# Altium schematic sheet Schematic_Orbita_Microcontroller.SchDoc
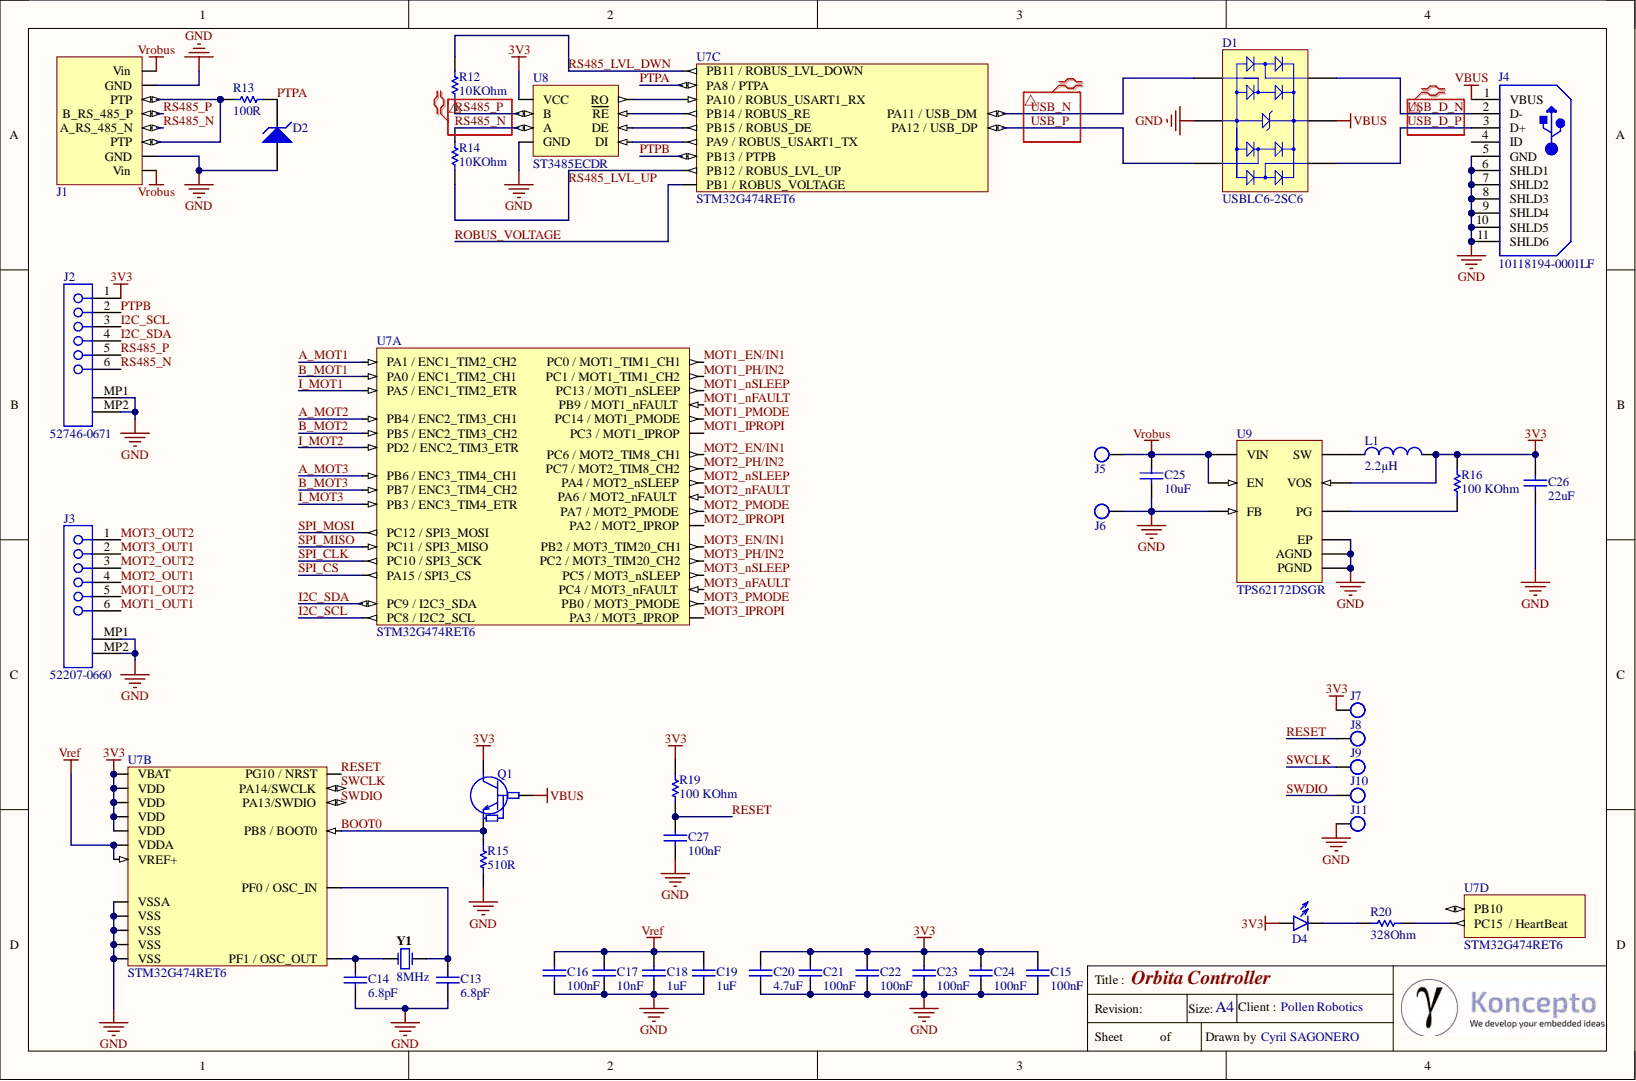
[diagram p0: Schematic_Orbita_Microcontroller.SchDoc]

## Parts
C13  Capacitance: 6.8pF  [CAPC1005X56X25LL10T15]  (hidden comment: 04025A6R8CAT2A)
C14  Capacitance: 6.8pF  [CAPC1005X56X25LL10T15]  (hidden comment: 04025A6R8CAT2A)
C15  Capacitance: 100nF  [CAPC1005X55X25ML05T10]  (hidden comment: GRM155R71C104KA88D)
C16  Capacitance: 100nF  [CAPC1005X60X30ML05T10]  (hidden comment: C0402C104K8PACTU)
C17  Capacitance: 10nF  [CAPC1005X55X30LL05T10]  (hidden comment: C0402C103K3RACTU)
C18  Capacitance: 1uF  [CAPC1005X60X30LL05T10]  (hidden comment: C0402C105K8PAC7867)
C19  Capacitance: 1uF  [CAPC1005X60X30LL05T10]  (hidden comment: C0402C105K8PAC7867)
C20  Capacitance: 4.7uF  [CAPC1005X55X25NL05T10]  (hidden comment: GRM155R60J475ME47D)
C21  Capacitance: 100nF  [CAPC1005X60X30ML05T10]  (hidden comment: C0402C104K8PACTU)
C22  Capacitance: 100nF  [CAPC1005X60X30ML05T10]  (hidden comment: C0402C104K8PACTU)
C23  Capacitance: 100nF  [CAPC1005X60X30ML05T10]  (hidden comment: C0402C104K8PACTU)
C24  Capacitance: 100nF  [CAPC1005X60X30ML05T10]  (hidden comment: C0402C104K8PACTU)
C25  Capacitance: 10uF  [CAPC2013X135X45ML10T25]  (hidden comment: GRM21BR61E106KA73L)
C26  value 22uF  Capacitance: 22uF  [CAPC0805(2012)145_M]  (hidden comment: CAP 22uF 6.3V 0805(2012))
C27  Capacitance: 100nF  [CAPC1005X60X30ML05T10]  (hidden comment: C0402C104K8PACTU)
D1  USBLC6-2SC6  [STM-SOT23-6L_V]
D2  MMSZ5226BT1G  [ONSC-SOD-123-2-425-04_V]  (value not drawn)
D4  APHD1608LVBC/D  [L0603]  (value not drawn)
J1  DF11-8DP-2DSA(08)  [DF11-8DP-2DSA]  (value not drawn)
J2  52746-0671  [MOLX-52746-0671]
J3  52207-0660  [MOLX-52207-0660]
J4  10118194-0001LF  [FCI-10118194-0001LF-5_V]
J5  Power Slot Through Hole  [Power_Slot]  (value not drawn)
J6  Power Slot Through Hole  [Power_Slot]  (value not drawn)
J7  Power Slot Through Hole  [Prog_Slot]  (value not drawn)
J8  Power Slot Through Hole  [Prog_Slot]  (value not drawn)
J9  Power Slot Through Hole  [Prog_Slot]  (value not drawn)
J10  Power Slot Through Hole  [Prog_Slot]  (value not drawn)
J11  Power Slot Through Hole  [Prog_Slot]  (value not drawn)
L1  Inductance: 2.2µH  [INDC2013X140X50LL20T20]  (hidden comment: BRC2012T2R2MD)
Q1  DTC143ZMT2L  [SOT-723]  (value not drawn)
R12  Resistance: 10KOhm  [RESC1005X40X25LL05T05]  (hidden comment: ERJ2RKF1002X)
R13  Resistance: 100R  [RESC0402(1005)_N]  (hidden comment: 100R 1% 0402(1005))
R14  Resistance: 10KOhm  [RESC1005X40X25LL05T05]  (hidden comment: ERJ2RKF1002X)
R15  Resistance: 510R  [RESC1005X40X25LL05T05]  (hidden comment: CRCW0402510RFKED)
R16  ERJ-2RKF1003X  [RESC1005X40X25LL05T05]  (value not drawn)
R19  Resistance: 100 KOhm  [RESC1005X40X25LL05T05]  (hidden comment: ERJ-2RKF1003X)
R20  Resistance: 328Ohm  [RESC1608X55X25ML10T15]  (hidden comment: RT0603DRE07328RL)
U7  STM32G474RET6  [LQFP64]  (parts A,B,C,D)
U8  ST3485ECDR  [SO8]
U9  TPS62172DSGR  [DSG0008A_V]
Y1  Frequency: 8MHz  [NDK-NX3225GD-8MHZ-STD-CRA-3_V]  (hidden comment: NX3225GD-8MHZ-STD-CRA-3)

## Nets
3V3: C15.1 C20.1 C21.1 C22.1 C23.1 C24.1 C26.2 D4.2 J2.1 J7.1 L1.2 Q1.3 R16.1 R19.2 U7.1(VBAT) U7.16(VDD) U7.32(VDD) U7.48(VDD) U7.64(VDD) U8.8(VCC) U9.6(VOS)
GND: C13.2 C14.2 C15.2 C16.2 C17.2 C18.2 C19.2 C20.2 C21.2 C22.2 C23.2 C24.2 C25.1 C26.1 C27.2 D1.2 D2.2 J1.2(GND) J1.7(GND) J2.MP1 J2.MP2 J3.MP1 J3.MP2 J4.5(GND) J4.6(SHLD1) J4.7(SHLD2) J4.8(SHLD3) J4.9(SHLD4) J4.10(SHLD5) J4.11(SHLD6) J6.1 J11.1 R15.1 U7.15(VSS) U7.27(VSSA) U7.31(VSS) U7.47(VSS) U7.63(VSS) U8.5(GND) U9.1(PGND) U9.4(AGND) U9.5(FB) U9.9(EP)
VBUS: D1.5 J4.1(VBUS) Q1.1
Vref: C16.1 C17.1 C18.1 C19.1 U7.28(VREF+) U7.29(VDDA)
Vrobus: C25.2 J1.1(Vin) J1.8(Vin) J5.1 U9.2(VIN) U9.3(EN)
A_MOT1: U7.13(PA1_/_ENC1_TIM2_CH2)
A_MOT2: U7.57(PB4_/_ENC2_TIM3_CH1)
A_MOT3: U7.59(PB6_/_ENC3_TIM4_CH1)
B_MOT1: U7.12(PA0_/_ENC1_TIM2_CH1)
B_MOT2: U7.58(PB5_/_ENC2_TIM3_CH2)
B_MOT3: U7.60(PB7_/_ENC3_TIM4_CH2)
BOOT0: Q1.2 R15.2 U7.61(PB8_/_BOOT0)
I2C_SCL: J2.3 U7.40(PC8_/_I2C2_SCL)
I2C_SDA: J2.4 U7.41(PC9_/_I2C3_SDA)
I_MOT1: U7.19(PA5_/_ENC1_TIM2_ETR)
I_MOT2: U7.55(PD2_/_ENC2_TIM3_ETR)
I_MOT3: U7.56(PB3_/_ENC3_TIM4_ETR)
MOT1_EN/IN1: U7.8(PC0_/_MOT1_TIM1_CH1)
MOT1_IPROPI: U7.11(PC3_/_MOT1_IPROP)
MOT1_nFAULT: U7.62(PB9_/_MOT1_nFAULT)
MOT1_nSLEEP: U7.2(PC13_/_MOT1_nSLEEP)
MOT1_OUT1: J3.6
MOT1_OUT2: J3.5
MOT1_PH/IN2: U7.9(PC1_/_MOT1_TIM1_CH2)
MOT1_PMODE: U7.3(PC14_/_MOT1_PMODE)
MOT2_EN/IN1: U7.38(PC6_/_MOT2_TIM8_CH1)
MOT2_IPROPI: U7.14(PA2_/_MOT2_IPROP)
MOT2_nFAULT: U7.20(PA6_/_MOT2_nFAULT)
MOT2_nSLEEP: U7.18(PA4_/_MOT2_nSLEEP)
MOT2_OUT1: J3.4
MOT2_OUT2: J3.3
MOT2_PH/IN2: U7.39(PC7_/_MOT2_TIM8_CH2)
MOT2_PMODE: U7.21(PA7_/_MOT2_PMODE)
MOT3_EN/IN1: U7.26(PB2_/_MOT3_TIM20_CH1)
MOT3_IPROPI: U7.17(PA3_/_MOT3_IPROP)
MOT3_nFAULT: U7.22(PC4_/_MOT3_nFAULT)
MOT3_nSLEEP: U7.23(PC5_/_MOT3_nSLEEP)
MOT3_OUT1: J3.2
MOT3_OUT2: J3.1
MOT3_PH/IN2: U7.10(PC2_/_MOT3_TIM20_CH2)
MOT3_PMODE: U7.24(PB0_/_MOT3_PMODE)
PTPA: D2.1 R13.1 U7.42(PA8_/_PTPA)
PTPB: J2.2 U7.35(PB13_/_PTPB)
RESET: C27.1 J8.1 R19.1 U7.7(PG10_/_NRST)
ROBUS_VOLTAGE: U7.25(PB1_/_ROBUS_VOLTAGE)
RS485_LVL_DWN: R12.2 U7.33(PB11_/_ROBUS_LVL_DOWN)
RS485_LVL_UP: R14.1 U7.34(PB12_/_ROBUS_LVL_UP)
RS485_N: J1.5(A_RS_485_N) J2.6 R14.2 U8.6(A)
RS485_P: J1.4(B_RS_485_P) J2.5 R12.1 U8.7(B)
SPI_CLK: U7.52(PC10_/_SPI3_SCK)
SPI_CS: U7.51(PA15_/_SPI3_CS)
SPI_MISO: U7.53(PC11_/_SPI3_MISO)
SPI_MOSI: U7.54(PC12_/_SPI3_MOSI)
SWCLK: J9.1 U7.50(PA14/SWCLK)
SWDIO: J10.1 U7.49(PA13/SWDIO)
USB_D_N: D1.6 J4.2(D-)
USB_D_P: D1.4 J4.3(D+)
USB_N: D1.1 U7.45(PA11_/_USB_DM)
USB_P: D1.3 U7.46(PA12_/_USB_DP)
NetC13_1: C13.1 U7.5(PF0_/_OSC_IN) Y1.1
NetC14_1: C14.1 U7.6(PF1_/_OSC_OUT) Y1.2
NetD4_1: D4.1 R20.2
NetJ1_3: J1.3(PTP) J1.6(PTP) R13.2
NetL1_1: L1.1 U9.7(SW)
NetR16_2: R16.2 U9.8(PG)
NetR20_1: R20.1 U7.4(PC15)
NetU7_36: U7.36(PB14_/_ROBUS_RE) U8.2(RE)
NetU7_37: U7.37(PB15_/_ROBUS_DE) U8.3(DE)
NetU7_43: U7.43(PA9_/_ROBUS_USART1_TX) U8.4(DI)
NetU7_44: U7.44(PA10_/_ROBUS_USART1_RX) U8.1(RO)

Not connected: J4 4(ID); U7 30(PB10)
